# Altium schematic sheet Gmsl2_des2.SchDoc
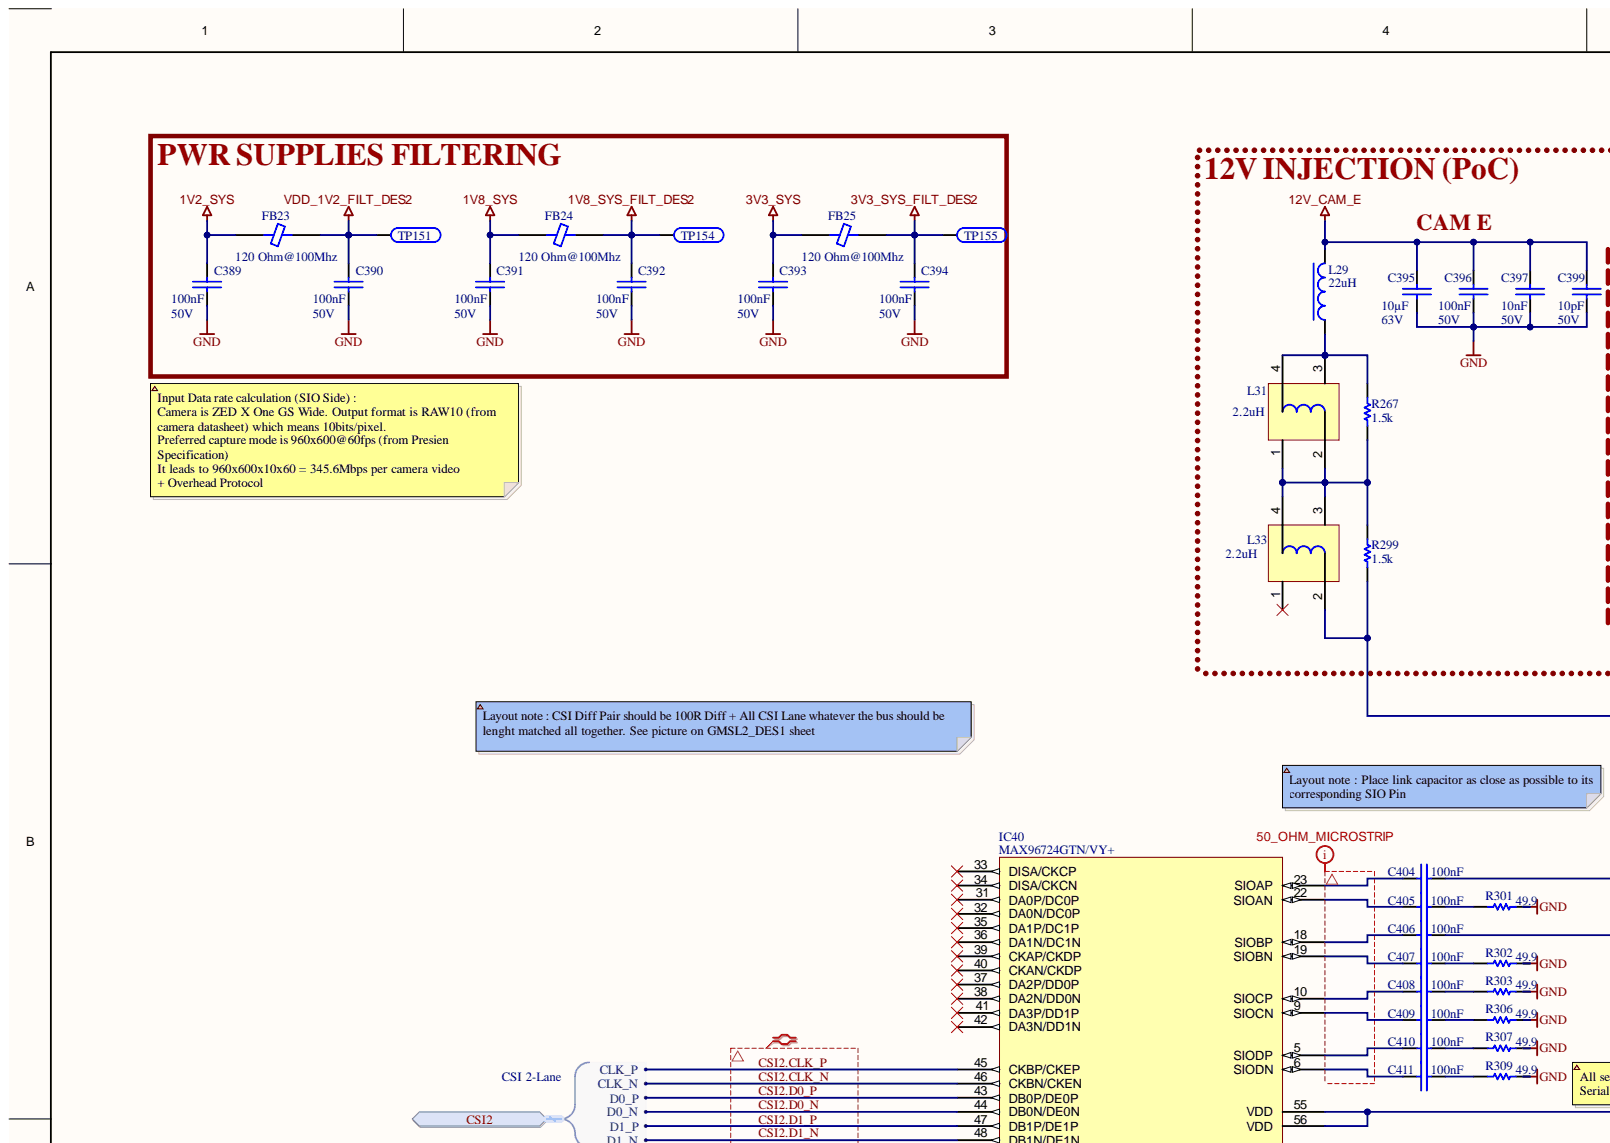
[diagram p0: Gmsl2_des2.SchDoc, top left]
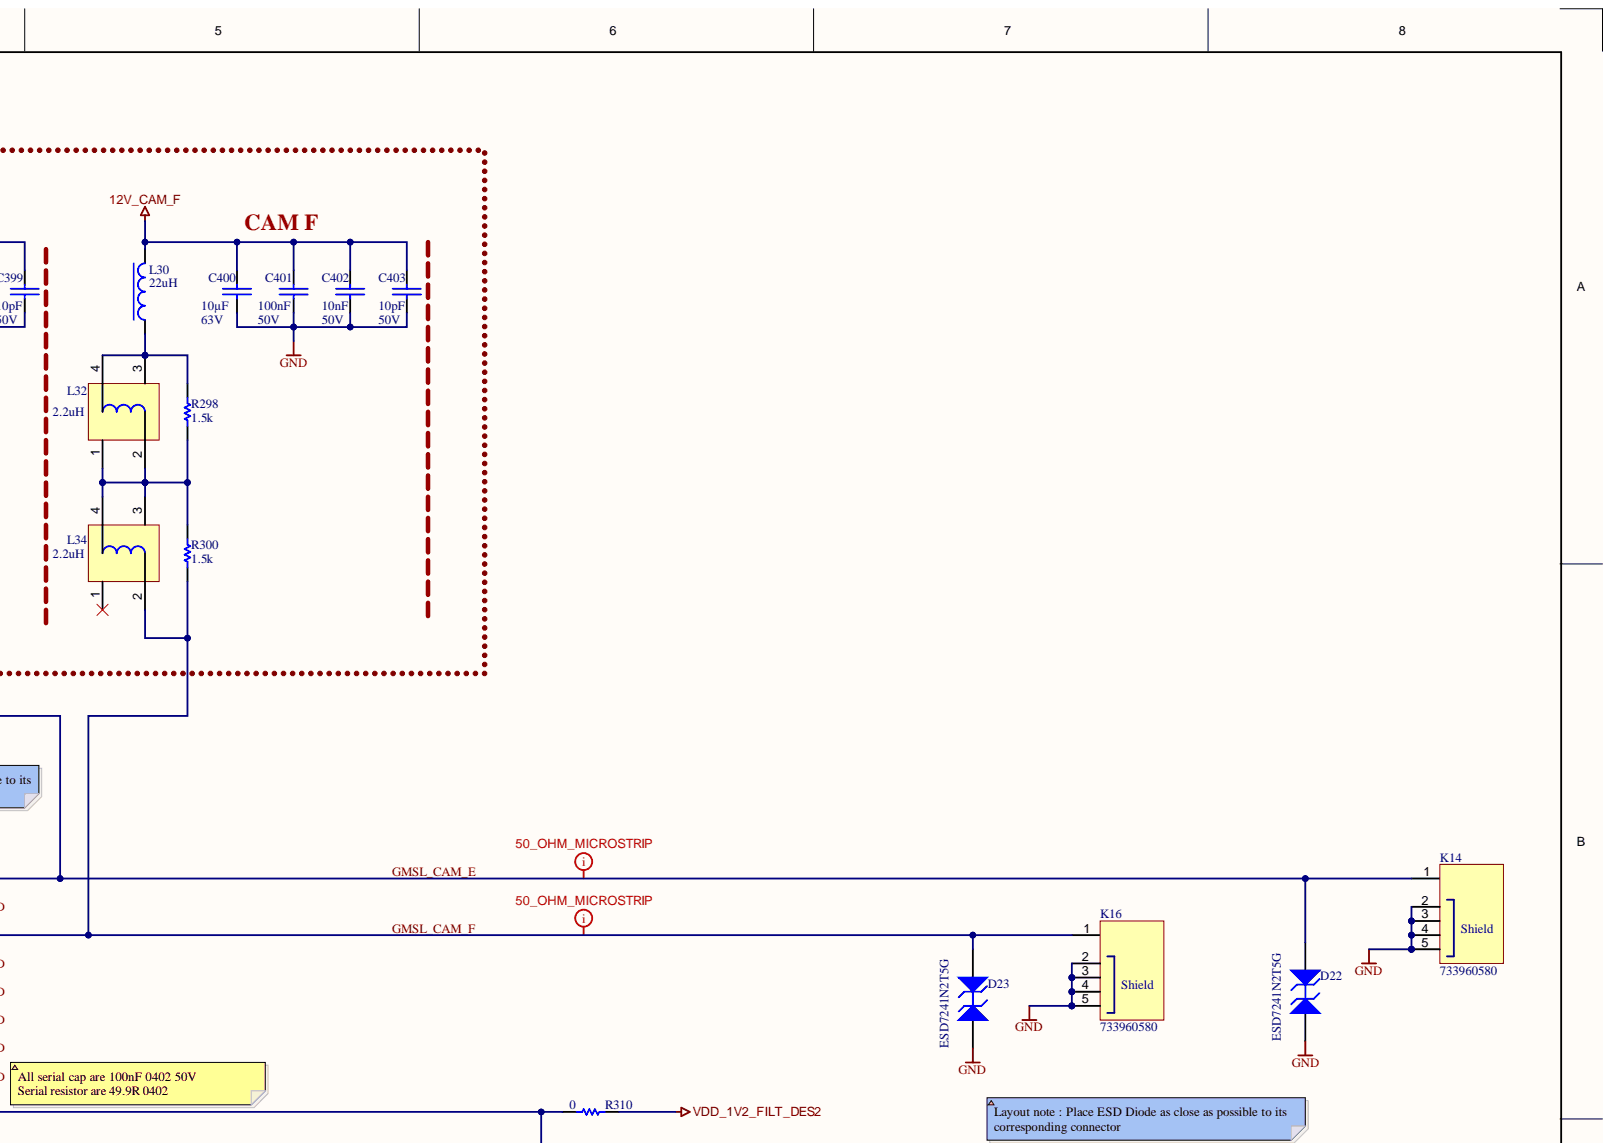
[diagram p1: Gmsl2_des2.SchDoc, top right]
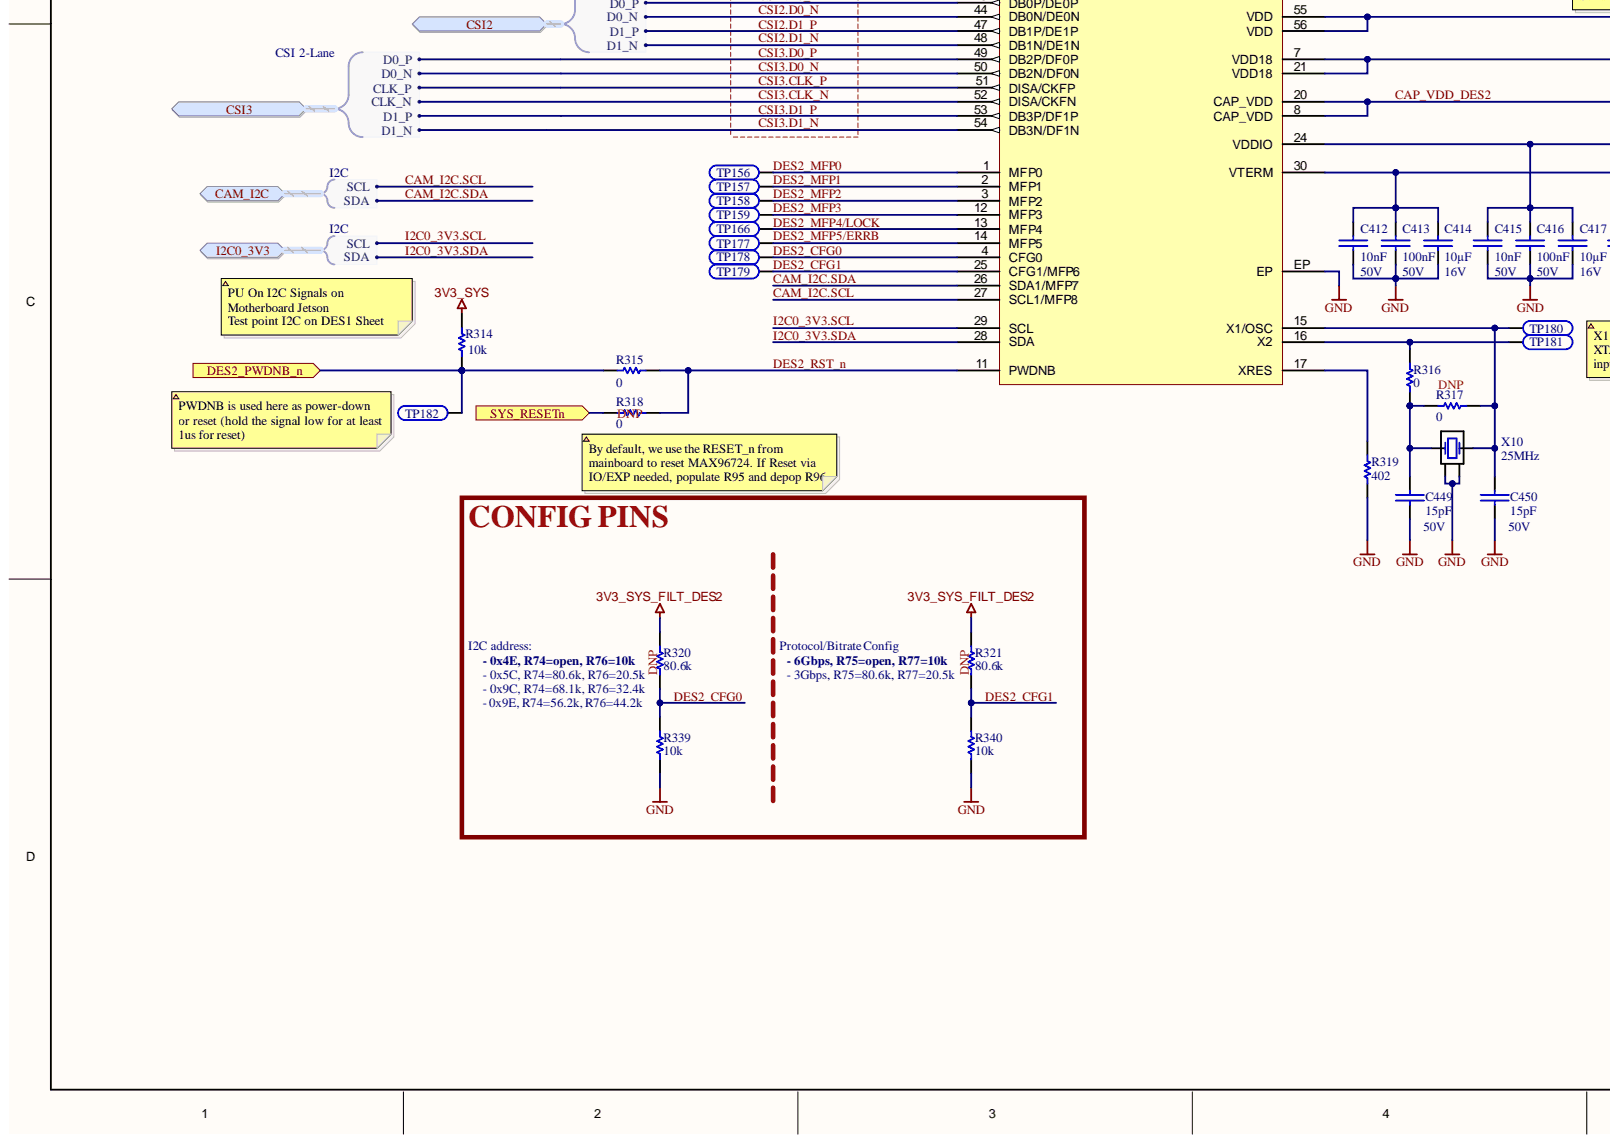
[diagram p2: Gmsl2_des2.SchDoc, bottom left]
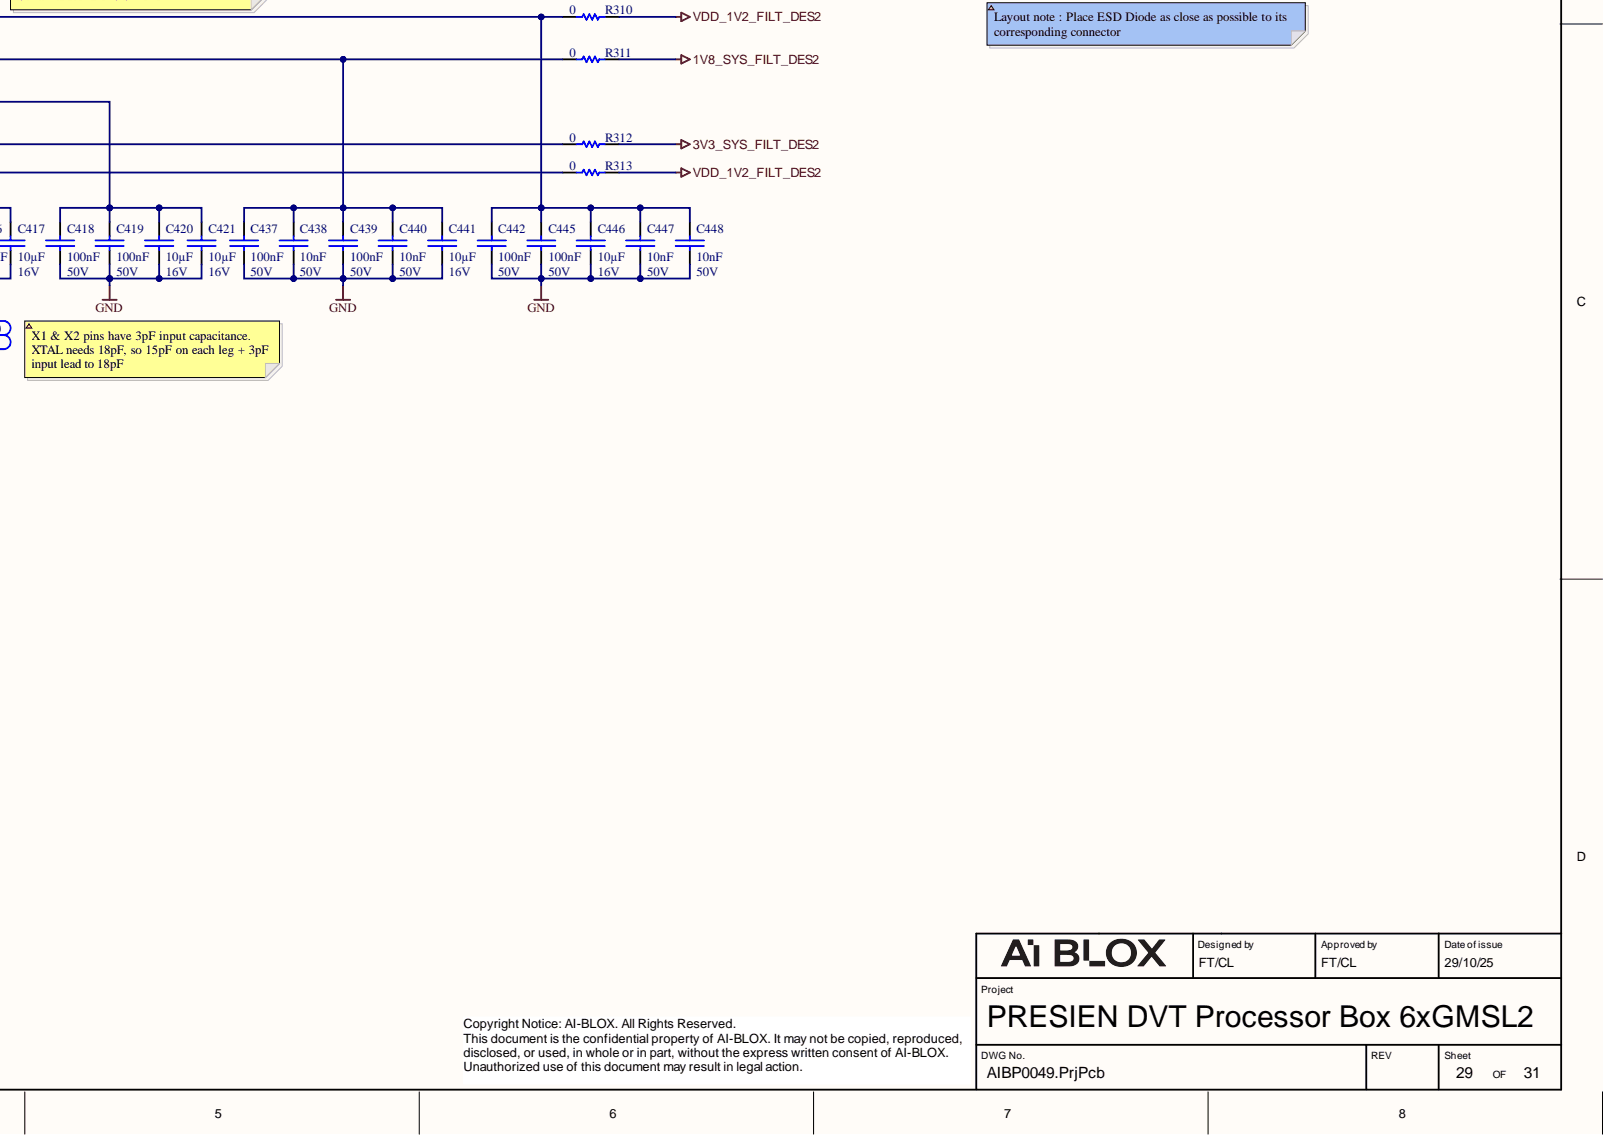
[diagram p3: Gmsl2_des2.SchDoc, bottom right]

## Parts
C389  value 100nF  Voltage: 50V  [CAP0603-M]  (hidden comment: CC0603KPX7R9BB104)
C390  value 100nF  Voltage: 50V  [CAP0603-M]  (hidden comment: CC0603KPX7R9BB104)
C391  value 100nF  Voltage: 50V  [CAP0603-M]  (hidden comment: CC0603KPX7R9BB104)
C392  value 100nF  Voltage: 50V  [CAP0603-M]  (hidden comment: CC0603KPX7R9BB104)
C393  value 100nF  Voltage: 50V  [CAP0603-M]  (hidden comment: CC0603KPX7R9BB104)
C394  value 100nF  Voltage: 50V  [CAP0603-M]  (hidden comment: CC0603KPX7R9BB104)
C395  value 10µF  Voltage: 63V  [CAP1210-CAPC3225X27N]  (hidden comment: GRM32ER71J106KA12L)
C396  value 100nF  Voltage: 50V  [CAP0603-M]  (hidden comment: CC0603KPX7R9BB104)
C397  value 10nF  Voltage: 50V  [CAP0603-M]  (hidden comment: CC0603KRX7R9BB103)
C399  value 10pF  Voltage: 50V  [CAP0603-M]  (hidden comment: CL10C100CB8NNNC)
C400  value 10µF  Voltage: 63V  [CAP1210-CAPC3225X27N]  (hidden comment: GRM32ER71J106KA12L)
C401  value 100nF  Voltage: 50V  [CAP0603-M]  (hidden comment: CC0603KPX7R9BB104)
C402  value 10nF  Voltage: 50V  [CAP0603-M]  (hidden comment: CC0603KRX7R9BB103)
C403  value 10pF  Voltage: 50V  [CAP0603-M]  (hidden comment: CL10C100CB8NNNC)
C404  value 100nF  [CAP0402-M]  (hidden comment: GRM155C71H104KE19)
C405  value 100nF  [CAP0402-M]  (hidden comment: GRM155C71H104KE19)
C406  value 100nF  [CAP0402-M]  (hidden comment: GRM155C71H104KE19)
C407  value 100nF  [CAP0402-M]  (hidden comment: GRM155C71H104KE19)
C408  value 100nF  [CAP0402-M]  (hidden comment: GRM155C71H104KE19)
C409  value 100nF  [CAP0402-M]  (hidden comment: GRM155C71H104KE19)
C410  value 100nF  [CAP0402-M]  (hidden comment: GRM155C71H104KE19)
C411  value 100nF  [CAP0402-M]  (hidden comment: GRM155C71H104KE19)
C412  value 10nF  Voltage: 50V  [CAP0603-M]  (hidden comment: CC0603KRX7R9BB103)
C413  value 100nF  Voltage: 50V  [CAP0603-M]  (hidden comment: CC0603KPX7R9BB104)
C414  value 10µF  Voltage: 16V  [CAP0805-M]  (hidden comment: CGA4J1X5R1C106K125AC)
C415  value 10nF  Voltage: 50V  [CAP0603-M]  (hidden comment: CC0603KRX7R9BB103)
C416  value 100nF  Voltage: 50V  [CAP0603-M]  (hidden comment: CC0603KPX7R9BB104)
C417  value 10µF  Voltage: 16V  [CAP0805-M]  (hidden comment: CGA4J1X5R1C106K125AC)
C418  value 100nF  Voltage: 50V  [CAP0603-M]  (hidden comment: CC0603KPX7R9BB104)
C419  value 100nF  Voltage: 50V  [CAP0603-M]  (hidden comment: CC0603KPX7R9BB104)
C420  value 10µF  Voltage: 16V  [CAP0805-M]  (hidden comment: CGA4J1X5R1C106K125AC)
C421  value 10µF  Voltage: 16V  [CAP0805-M]  (hidden comment: CGA4J1X5R1C106K125AC)
C437  value 100nF  Voltage: 50V  [CAP0603-M]  (hidden comment: CC0603KPX7R9BB104)
C438  value 10nF  Voltage: 50V  [CAP0603-M]  (hidden comment: CC0603KRX7R9BB103)
C439  value 100nF  Voltage: 50V  [CAP0603-M]  (hidden comment: CC0603KPX7R9BB104)
C440  value 10nF  Voltage: 50V  [CAP0603-M]  (hidden comment: CC0603KRX7R9BB103)
C441  value 10µF  Voltage: 16V  [CAP0805-M]  (hidden comment: CGA4J1X5R1C106K125AC)
C442  value 100nF  Voltage: 50V  [CAP0603-M]  (hidden comment: CC0603KPX7R9BB104)
C445  value 100nF  Voltage: 50V  [CAP0603-M]  (hidden comment: CC0603KPX7R9BB104)
C446  value 10µF  Voltage: 16V  [CAP0805-M]  (hidden comment: CGA4J1X5R1C106K125AC)
C447  value 10nF  Voltage: 50V  [CAP0603-M]  (hidden comment: CC0603KRX7R9BB103)
C448  value 10nF  Voltage: 50V  [CAP0603-M]  (hidden comment: CC0603KRX7R9BB103)
C449  value 15pF  Voltage: 50V  [CAP0603-M]  (hidden comment: CC0603JRNPO9BN150)
C450  value 15pF  Voltage: 50V  [CAP0603-M]  (hidden comment: CC0603JRNPO9BN150)
D22  ESD7241N2T5G  [ONSEMI_X2DFN]
D23  ESD7241N2T5G  [ONSEMI_X2DFN]
FB23  value 120 Ohm@100Mhz  [FER0603]  (hidden comment: BLM18EG121SN1D)
FB24  value 120 Ohm@100Mhz  [FER0603]  (hidden comment: BLM18EG121SN1D)
FB25  value 120 Ohm@100Mhz  [FER0603]  (hidden comment: BLM18EG121SN1D)
IC40  MAX96724GTN/VY+  [ANALOG_56TQFN-SW-8x8]
K14  733960580  [733960580]
K16  733960580  [733960580]
L29  value 22uH  [INDP5350X30N]  (hidden comment: VLS5030EX-220M-D)
L30  value 22uH  [INDP5350X30N]  (hidden comment: VLS5030EX-220M-D)
L31  value 2.2uH  ["]  (hidden comment: ADL3225VM-2R2M-TL001)
L32  value 2.2uH  ["]  (hidden comment: ADL3225VM-2R2M-TL001)
L33  value 2.2uH  ["]  (hidden comment: ADL3225VM-2R2M-TL001)
L34  value 2.2uH  ["]  (hidden comment: ADL3225VM-2R2M-TL001)
R267  value 1.5k  [RES0402]  (hidden comment: RC0402FR-071K5L)
R298  value 1.5k  [RES0402]  (hidden comment: RC0402FR-071K5L)
R299  value 1.5k  [RES0402]  (hidden comment: RC0402FR-071K5L)
R300  value 1.5k  [RES0402]  (hidden comment: RC0402FR-071K5L)
R301  value 49.9  [RES0402]  (hidden comment: RC0402FR-0749R9P)
R302  value 49.9  [RES0402]  (hidden comment: RC0402FR-0749R9P)
R303  value 49.9  [RES0402]  (hidden comment: RC0402FR-0749R9P)
R306  value 49.9  [RES0402]  (hidden comment: RC0402FR-0749R9P)
R307  value 49.9  [RES0402]  (hidden comment: RC0402FR-0749R9P)
R309  value 49.9  [RES0402]  (hidden comment: RC0402FR-0749R9P)
R310  value 0  [RES0603]  (hidden comment: RC0603FR-070RL)
R311  value 0  [RES0603]  (hidden comment: RC0603FR-070RL)
R312  value 0  [RES0603]  (hidden comment: RC0603FR-070RL)
R313  value 0  [RES0603]  (hidden comment: RC0603FR-070RL)
R314  value 10k  [RES0603]  (hidden comment: RMCF0603FT10K0)
R315  value 0  [RES0603]  (hidden comment: RC0603FR-070RL)
R316  value 0  [RES0603]  (hidden comment: RC0603FR-070RL)
R317  value 0  [RES0603]  (hidden comment: RC0603FR-070RL)
R318  value 0  [RES0603]  (hidden comment: RC0603FR-070RL)
R319  value 402  [RES0603]  (hidden comment: RC0603FR-07402RL)
R320  value 80.6k  [RES0603]  (hidden comment: RC0603FR-0780K6L)
R321  value 80.6k  [RES0603]  (hidden comment: RC0603FR-0780K6L)
R339  value 10k  [RES0603]  (hidden comment: RC0603FR-0710KL)
R340  value 10k  [RES0603]  (hidden comment: RC0603FR-0710KL)
TP151  Test_Point_1  [Test_Point_1]  (value not drawn)  (no BOM)
TP154  Test_Point_1  [Test_Point_1]  (value not drawn)  (no BOM)
TP155  Test_Point_1  [Test_Point_1]  (value not drawn)  (no BOM)
TP156  Test_Point_1  [Test_Point_1]  (value not drawn)  (no BOM)
TP157  Test_Point_1  [Test_Point_1]  (value not drawn)  (no BOM)
TP158  Test_Point_1  [Test_Point_1]  (value not drawn)  (no BOM)
TP159  Test_Point_1  [Test_Point_1]  (value not drawn)  (no BOM)
TP166  Test_Point_1  [Test_Point_1]  (value not drawn)  (no BOM)
TP177  Test_Point_1  [Test_Point_1]  (value not drawn)  (no BOM)
TP178  Test_Point_1  [Test_Point_1]  (value not drawn)  (no BOM)
TP179  Test_Point_1  [Test_Point_1]  (value not drawn)  (no BOM)
TP180  Test_Point_1  [Test_Point_1]  (value not drawn)  (no BOM)
TP181  Test_Point_1  [Test_Point_1]  (value not drawn)  (no BOM)
TP182  Test_Point_1  [Test_Point_1]  (value not drawn)  (no BOM)
X10  value 25MHz  [DIODES FL SERIE]  (hidden comment: FL2500133)

## Ports
CAM_I2C, CAM_I2C.SCL, CAM_I2C.SDA, CSI2, CSI2.CLK_N, CSI2.CLK_P, CSI2.D0_N, CSI2.D0_P, CSI2.D1_N, CSI2.D1_P, CSI3, CSI3.CLK_N, CSI3.CLK_P, CSI3.D0_N, CSI3.D0_P, CSI3.D1_N, CSI3.D1_P, DES2_PWDNB_n, I2C0_3V3, I2C0_3V3.SCL, I2C0_3V3.SDA, SYS_RESETn

## Nets
1V2_SYS: C389.1 FB23.1
1V8_SYS: C391.1 FB24.1
1V8_SYS_FILT_DES2: C392.1 FB24.2 R311.2 TP154.1
3V3_SYS: C393.1 FB25.1 R314.2
3V3_SYS_FILT_DES2: C394.1 FB25.2 R312.2 R320.2 R321.2 TP155.1
12V_CAM_E: C395.1 C396.1 C397.1 C399.1 L29.2
12V_CAM_F: C400.1 C401.1 C402.1 C403.1 L30.2
GND: C389.2 C390.2 C391.2 C392.2 C393.2 C394.2 C395.2 C396.2 C397.2 C399.2 C400.2 C401.2 C402.2 C403.2 C412.2 C413.2 C414.2 C415.2 C416.2 C417.2 C418.2 C419.2 C420.2 C421.2 C437.2 C438.2 C439.2 C440.2 C441.2 C442.2 C445.2 C446.2 C447.2 C448.2 C449.2 C450.2 D22.A D23.A IC40.EP K14.2 K14.3 K14.4(Shield) K14.5 K16.2 K16.3 K16.4(Shield) K16.5 R301.2 R302.2 R303.2 R306.2 R307.2 R309.2 R319.1 R339.1 R340.1 X10.2 X10.4
VDD_1V2_FILT_DES2: C390.1 FB23.2 R310.2 R313.2 TP151.1
CAM_I2C.SCL: IC40.27(SCL1/MFP8) ; port CAM_I2C
CAM_I2C.SDA: IC40.26(SDA1/MFP7) ; port CAM_I2C
CAP_VDD_DES2: C418.1 C419.1 C420.1 C421.1 IC40.8(CAP_VDD) IC40.20(CAP_VDD)
CSI2.CLK_N: IC40.46(CKBN/CKEN) ; port CSI2
CSI2.CLK_P: IC40.45(CKBP/CKEP) ; port CSI2
CSI2.D0_N: IC40.44(DB0N/DE0N) ; port CSI2
CSI2.D0_P: IC40.43(DB0P/DE0P) ; port CSI2
CSI2.D1_N: IC40.48(DB1N/DE1N) ; port CSI2
CSI2.D1_P: IC40.47(DB1P/DE1P) ; port CSI2
CSI3.CLK_N: IC40.52(DISA/CKFN) ; port CSI3
CSI3.CLK_P: IC40.51(DISA/CKFP) ; port CSI3
CSI3.D0_N: IC40.50(DB2N/DF0N) ; port CSI3
CSI3.D0_P: IC40.49(DB2P/DF0P) ; port CSI3
CSI3.D1_N: IC40.54(DB3N/DF1N) ; port CSI3
CSI3.D1_P: IC40.53(DB3P/DF1P) ; port CSI3
DES2_CFG0: IC40.4(CFG0) R320.1 R339.2 TP178.1
DES2_CFG1: IC40.25(CFG1/MFP6) R321.1 R340.2 TP179.1
DES2_MFP0: IC40.1(MFP0) TP156.1
DES2_MFP1: IC40.2(MFP1) TP157.1
DES2_MFP2: IC40.3(MFP2) TP158.1
DES2_MFP3: IC40.12(MFP3) TP159.1
DES2_MFP4/LOCK: IC40.13(MFP4) TP166.1
DES2_MFP5/ERRB: IC40.14(MFP5) TP177.1
DES2_PWDNB_n: R314.1 R315.2 TP182.1 ; port DES2_PWDNB_n
DES2_RST_n: IC40.11(PWDNB) R315.1 R318.1
GMSL_CAM_E: C404.2 D22.K K14.1 L33.2 R299.1
GMSL_CAM_F: C406.2 D23.K K16.1 L34.2 R300.1
I2C0_3V3.SCL: IC40.29(SCL) ; port I2C0_3V3
I2C0_3V3.SDA: IC40.28(SDA) ; port I2C0_3V3
SYS_RESETn: R318.2 ; port SYS_RESETn
NetC404_1: C404.1 IC40.23(SIOAP)
NetC405_1: C405.1 IC40.22(SIOAN)
NetC405_2: C405.2 R301.1
NetC406_1: C406.1 IC40.18(SIOBP)
NetC407_1: C407.1 IC40.19(SIOBN)
NetC407_2: C407.2 R302.1
NetC408_1: C408.1 IC40.10(SIOCP)
NetC408_2: C408.2 R303.1
NetC409_1: C409.1 IC40.9(SIOCN)
NetC409_2: C409.2 R306.1
NetC410_1: C410.1 IC40.5(SIODP)
NetC410_2: C410.2 R307.1
NetC411_1: C411.1 IC40.6(SIODN)
NetC411_2: C411.2 R309.1
NetC412_1: C412.1 C413.1 C414.1 IC40.30(VTERM) R313.1
NetC415_1: C415.1 C416.1 C417.1 IC40.24(VDDIO) R312.1
NetC437_1: C437.1 C438.1 C439.1 C440.1 C441.1 IC40.7(VDD18) IC40.21(VDD18) R311.1
NetC442_1: C442.1 C445.1 C446.1 C447.1 C448.1 IC40.55(VDD) IC40.56(VDD) R310.1
NetC449_1: C449.1 R316.1 R317.2 X10.3
NetC450_1: C450.1 IC40.15(X1/OSC) R317.1 TP180.1 X10.1
NetIC40_16: IC40.16(X2) R316.2 TP181.1
NetIC40_17: IC40.17(XRES) R319.2
NetL29_1: L29.1 L31.3 L31.4 R267.2
NetL30_1: L30.1 L32.3 L32.4 R298.2
NetL31_1: L31.1 L31.2 L33.3 L33.4 R267.1 R299.2
NetL32_1: L32.1 L32.2 L34.3 L34.4 R298.1 R300.2

Not connected: IC40 31(DA0P/DC0P) 32(DA0N/DC0P) 33(DISA/CKCP) 34(DISA/CKCN) 35(DA1P/DC1P) 36(DA1N/DC1N) 37(DA2P/DD0P) 38(DA2N/DD0N) 39(CKAP/CKDP) 40(CKAN/CKDP) 41(DA3P/DD1P) 42(DA3N/DD1N); L33 1; L34 1

## Library data of the parts
C389-C394 C396 C401 C413 C416 C418 C419 C437 C439 C442 C445 = CMP-81.02.0001-14: CAP CER 0.1UF 50V X7R 0603; Manufacturer 1: YAGEO; GRADE: NO; Case/Package: 0603; Component Kind: Standard; DatasheetDocument: https://datasheet.octopart.com/CC0603KPX7R9BB104-Yageo-datasheet-151948686.pdf; Internal Ref: 81.02.0001; Manufacturer Part Number 1: CC0603KPX7R9BB104; ROHS: YES; Library Name: AI Blox; Publisher: Hans Stevens
C395 C400 = CMP-81.02.0009-8: CAP CER 10UF 63V X7R 1210; Manufacturer 1: Murata; GRADE: NO; Case/Package: 1210; Component Kind: Standard; DatasheetDocument: https://octopart.com/datasheet/grm32er71j106ka12l-murata-33513537; Internal Ref: 81.02.0009; Manufacturer Part Number 1: GRM32ER71J106KA12L; ROHS: YES; Library Name: AI Blox; Publisher: Hans Stevens
C397 C402 C412 C415 C438 C440 C447 C448 = CMP-81.02.0030-9: CAP CER 0.010UF 50V X7R 0603; Manufacturer 1: YAGEO; GRADE: NO; Case/Package: 0603; Component Kind: Standard; DatasheetDocument: https://octopart.com/datasheet/cc0603krx7r9bb103-yageo-192938; Internal Ref: 81.02.0030; Manufacturer Part Number 1: CC0603KRX7R9BB103; ROHS: YES; Library Name: AI Blox; Publisher: Marc Goovaerts
C399 C403 = CMP-81.02.0020-9: CAP CER 10PF 50V C0G/NP0 0603; Manufacturer 1: Samsung; GRADE: NO; Case/Package: 0603; Component Kind: Standard; DatasheetDocument: https://octopart.com/datasheet/cl10c100cb8nnnc-samsung-19018981; Internal Ref: 81.02.0020; Manufacturer Part Number 1: CL10C100CB8NNNC; ROHS: YES; Library Name: AI Blox; Publisher: Hans Stevens
C404-C411 = CMP-81.02.0039-10: CAP CER 0.1UF 50V X7S 0402; Manufacturer 1: Murata; GRADE: NO; Tolerance: 10%; Case/Package: 0402; Component Kind: Standard; DatasheetDocument: https://octopart.com/datasheet/grm155c71h104ke19d-murata-18783362; Internal Ref: 81.02.0039; Manufacturer Part Number 1: GRM155C71H104KE19; ROHS: YES; Voltage: 50V; Library Name: AI Blox; Publisher: Hans Stevens
C414 C417 C420 C421 C441 C446 = CMP-81.02.0005-14: CAP CER 10UF 16V X5R 0805; Manufacturer 1: TDK; GRADE: NO; Case/Package: 0805; Component Kind: Standard; DatasheetDocument: https://octopart.com/datasheet/cga4j1x5r1c106k125ac-tdk-26014647; Internal Ref: 81.02.0005; Manufacturer Part Number 1: CGA4J1X5R1C106K125AC; ROHS: YES; Library Name: AI Blox; Publisher: Hans Stevens
C449 C450 = CMP-81.02.0070-4: CAP CER 15PF 50V C0G/NPO 0603; Manufacturer 1: YAGEO; GRADE: NO; Tolerance: 5%; Case/Package: 0603; Component Kind: Standard; DatasheetDocument: https://octopart.com/datasheet/cc0603jrnpo9bn150-yageo-39467672; Internal Ref: 81.02.0070; Manufacturer Part Number 1: CC0603JRNPO9BN150; ROHS: YES; Library Name: AI Blox
D22 D23 = CMP-81.09.0019-3: TVS DIODE 24VWM 48VC 2X2DFN; GRADE: NO; Case/Package: DFN2; Component Kind: Standard; DatasheetDocument: https://octopart.com/datasheet/esd7241n2t5g-onsemi-75535408; Internal Ref: 81.09.0019; ROHS: YES; Library Name: AI Blox; Publisher: Hans Stevens
FB23-FB25 = CMP-81.11.0002-2: FERRITE BEAD 120 OHM 0603 1LN; Manufacturer 1: Murata; GRADE: NO; Component Kind: Standard; DatasheetDocument: https://octopart.com/datasheet/blm18eg121sn1d-murata-368362; Internal Ref: 81.11.0002; Manufacturer Part Number 1: BLM18EG121SN1D; ROHS: YES; Supplier 1: Digikey; Supplier Part Number 1: 490-3991-1-ND; Library Name: AI Blox; Publisher: Hans Stevens
IC40 = CMP-81.04.0054-1: GMSL2/1 TUNNELING QUAD DESERIALI; Manufacturer 1: Analog; GRADE: NO; Component Kind: Standard; DatasheetDocument: https://www.analog.com/en/products/max96724.html?ADICID=SYND_WW_P682800_PF-octopart; Internal Ref: 81.04.0054; Manufacturer Part Number 1: MAX96724GTN/VY+; Package: 56-TQFN; ROHS: YES; Supplier 1: Mouser; Supplier Part Number 1: 700-MAX96724GTN/VY+; Library Name: AI Blox; Publisher: Hans Stevens
K14 K16 = CMP-81.03.0156-7: SMB R/A PCB RECEPTACLE; GRADE: NO; Component Kind: Standard; DatasheetDocument: https://octopart.com/73396-0580-molex-101557221; Internal Ref: 81.03.0156; ROHS: YES; Library Name: AI Blox; Publisher: Jeroen Wallyn
L29 L30 = CMP-81.11.0049-1: INDUCTORS FOR POWER CIRCUITS, AU; Manufacturer 1: TDK; GRADE: YES; Component Kind: Standard; DatasheetDocument: https://octopart.com/vls5030ex-220m-d-tdk-132322332; Internal Ref: 81.11.0049; Manufacturer Part Number 1: VLS5030EX-220M-D; ROHS: YES; Library Name: AI Blox; Publisher: Jeroen Wallyn
L31-L34 = CMP-81.11.0048-2: FIXED IND 2.2UH 1.22A 180MOHM SM; Manufacturer 1: TDK; GRADE: YES; Component Kind: Standard; DatasheetDocument: https://octopart.com/adl3225vm-2r2m-tl001-tdk-134204157; Internal Ref: 81.11.0048; Manufacturer Part Number 1: FIXED IND 2.2UH 1.22A 180MOHM SM; ROHS: YES; Library Name: AI Blox; Publisher: Jeroen Wallyn
R267 R298-R300 = CMP-81.01.0208-1: RES 1.5K OHM 1% 1/16W 0402; GRADE: NO; Case/Package: 0402; Component Kind: Standard; DatasheetDocument: https://www.yageogroup.com/content/datasheet/asset/file/PYU-RC_GROUP_51_ROHS_L; Internal Ref: 81.01.0208; ROHS: YES; Library Name: AI Blox; Publisher: FT
R301-R303 R306 R307 R309 = CMP-81.01.0067-5: RES SMD 49.9 OHM 1% 1/16W 0402; GRADE: NO; Case/Package: 0402; Component Kind: Standard; DatasheetDocument: https://octopart.com/datasheet/rc0402fr-0749r9p-yageo-47616041; Internal Ref: 81.01.0067; ROHS: YES; Library Name: AI Blox; Publisher: Hans Stevens
R310-R313 R315-R318 = CMP-81.01.0005-5: RES 0 OHM JUMPER 1/10W 0603; GRADE: NO; Case/Package: 0603; Component Kind: Standard; DatasheetDocument: https://octopart.com/datasheet/rc0603fr-070rl-yageo-1180803; Internal Ref: 81.01.0005; ROHS: YES; Library Name: AI Blox; Publisher: Hans Stevens
R314 = CMP-81.08.0001-1: RES 10K OHM 1% 1/10W 0603; Manufacturer 1: Stackpole Electronics Inc; GRADE: AEC-Q200; Case/Package: 0603; Component Kind: Standard; DatasheetDocument: https://datasheet.octopart.com/RMCF0603FT10K0-Stackpole-Electronics-datasheet-141193804.pdf; Internal Ref: 81.08.0001; Manufacturer Part Number 1: RMCF0603FT10K0; ROHS: YES; Library Name: AI Blox; Publisher: Hans Stevens
R319 = CMP-81.01.0066-5: RES 402 OHM 1% 1/10W 0603; GRADE: NO; Case/Package: 0603; Component Kind: Standard; DatasheetDocument: https://octopart.com/datasheet/rc0603fr-07402rl-yageo-1201043; Internal Ref: 81.01.0066; ROHS: YES; Library Name: AI Blox; Publisher: Hans Stevens
R320 R321 = CMP-81.01.0069-5: RES 80K6 OHM 1% 1/10W 0603; GRADE: NO; Case/Package: 0603; Component Kind: Standard; DatasheetDocument: https://octopart.com/datasheet/rc0603fr-0780k6l-yageo-1194262; Internal Ref: 81.01.0069; ROHS: YES; Library Name: AI Blox; Publisher: Marc Goovaerts
R339 R340 = CMP-81.01.0021-5: RES 10K OHM 1% 1/10W 0603; GRADE: NO; Case/Package: 0603; Component Kind: Standard; DatasheetDocument: https://octopart.com/datasheet/rc0603fr-0710kl-yageo-40025538; Internal Ref: 81.01.0021; ROHS: YES; Library Name: AI Blox; Publisher: Hans Stevens
TP151 TP154-TP159 TP166 TP177-TP182 = CMP-81.00.0006-9: 0.8mm testpoint; Library Name: AI Blox
X10 = CMP-81.12.0001-6: CRYSTAL 25.0000MHZ 18PF SMD; Manufacturer 1: Diodes Inc.; GRADE: NO; Component Kind: Standard; DatasheetDocument: https://www.diodes.com/assets/Datasheets/FL.pdf; Internal Ref: 81.12.0001; Manufacturer Part Number 1: FL2500133; ROHS: YES; Supplier 1: Digikey; Supplier Part Number 1: FL2500133CT-ND; Library Name: AI Blox; Publisher: Hans Stevens
